# Altium schematic sheet IMX7S_MEMORY.SchDoc
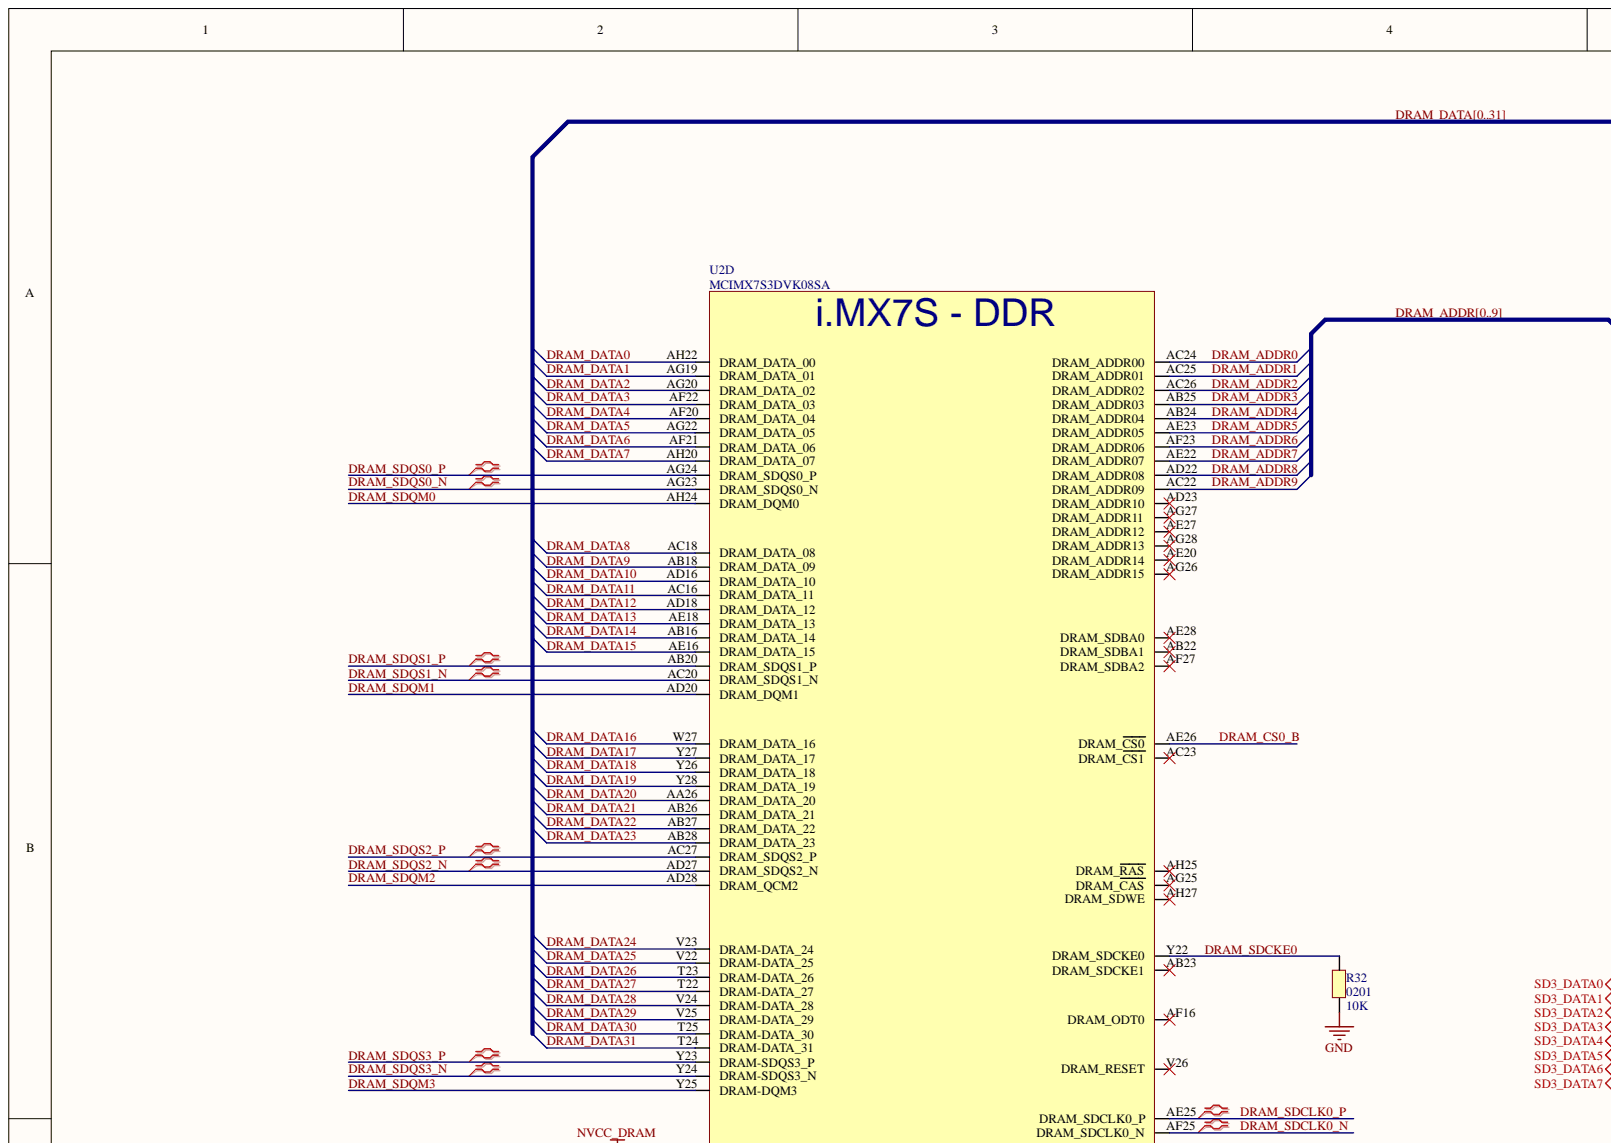
[diagram p0: IMX7S_MEMORY.SchDoc, top left]
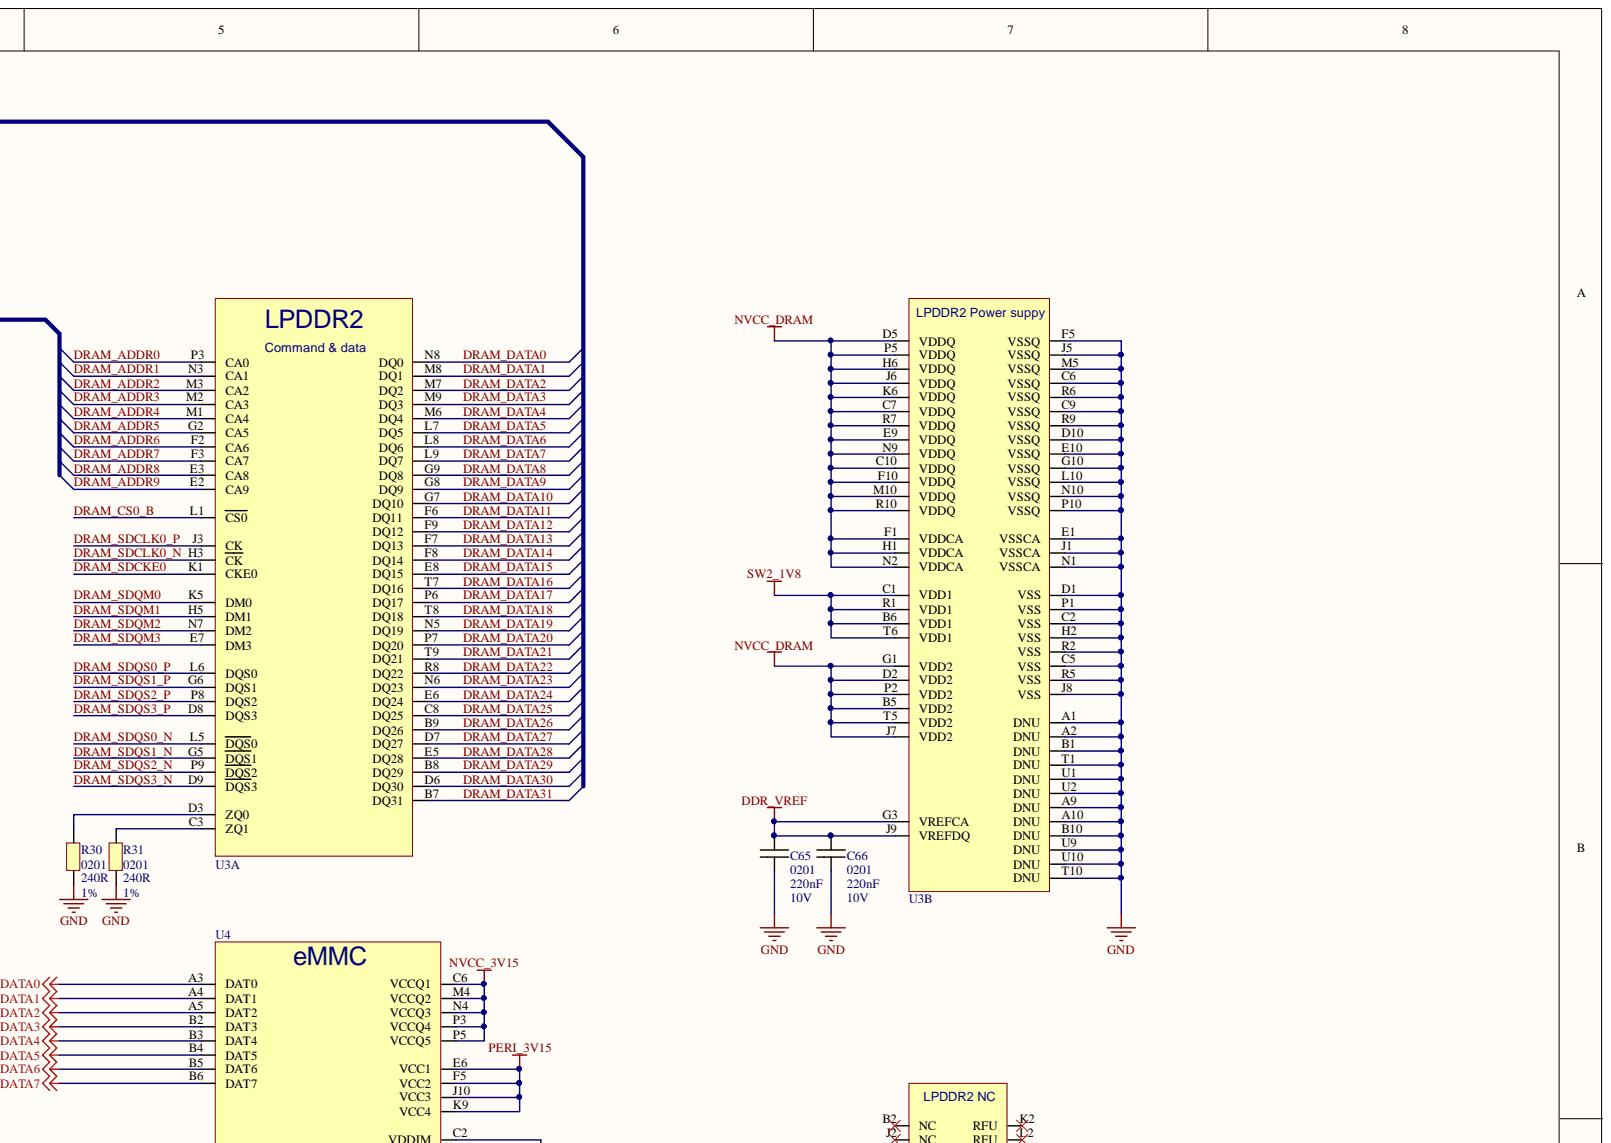
[diagram p1: IMX7S_MEMORY.SchDoc, top right]
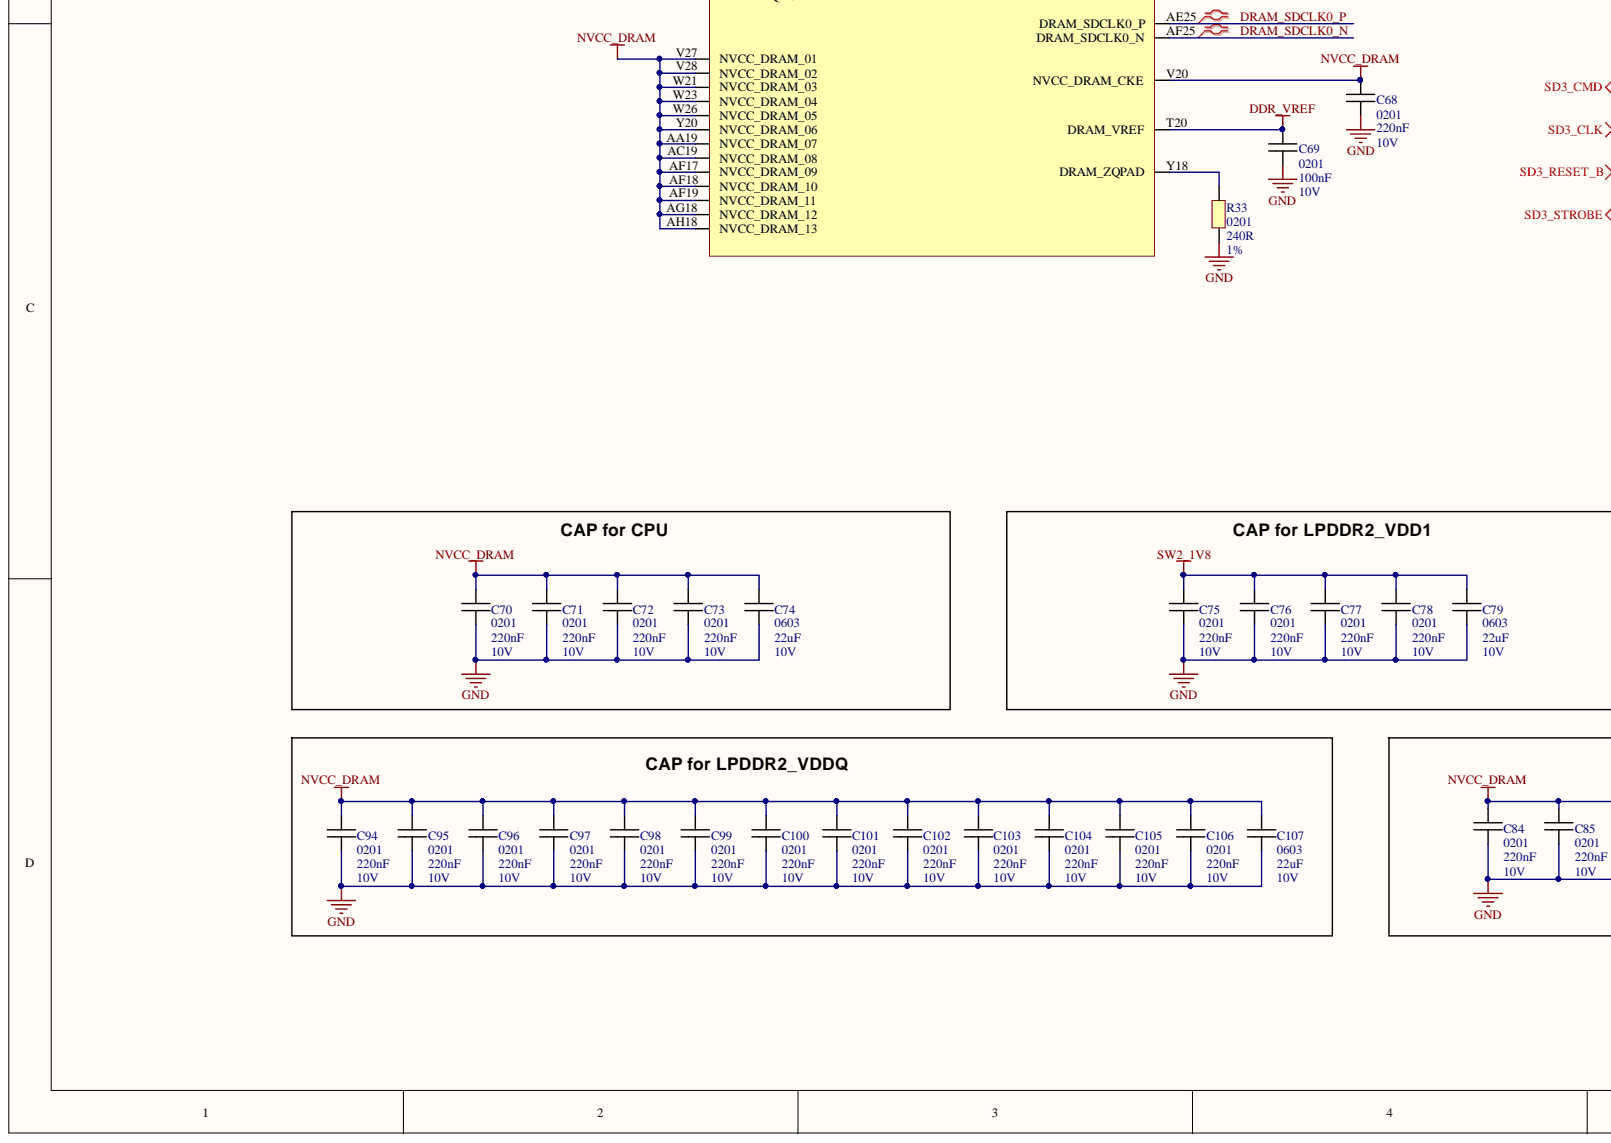
[diagram p2: IMX7S_MEMORY.SchDoc, bottom left]
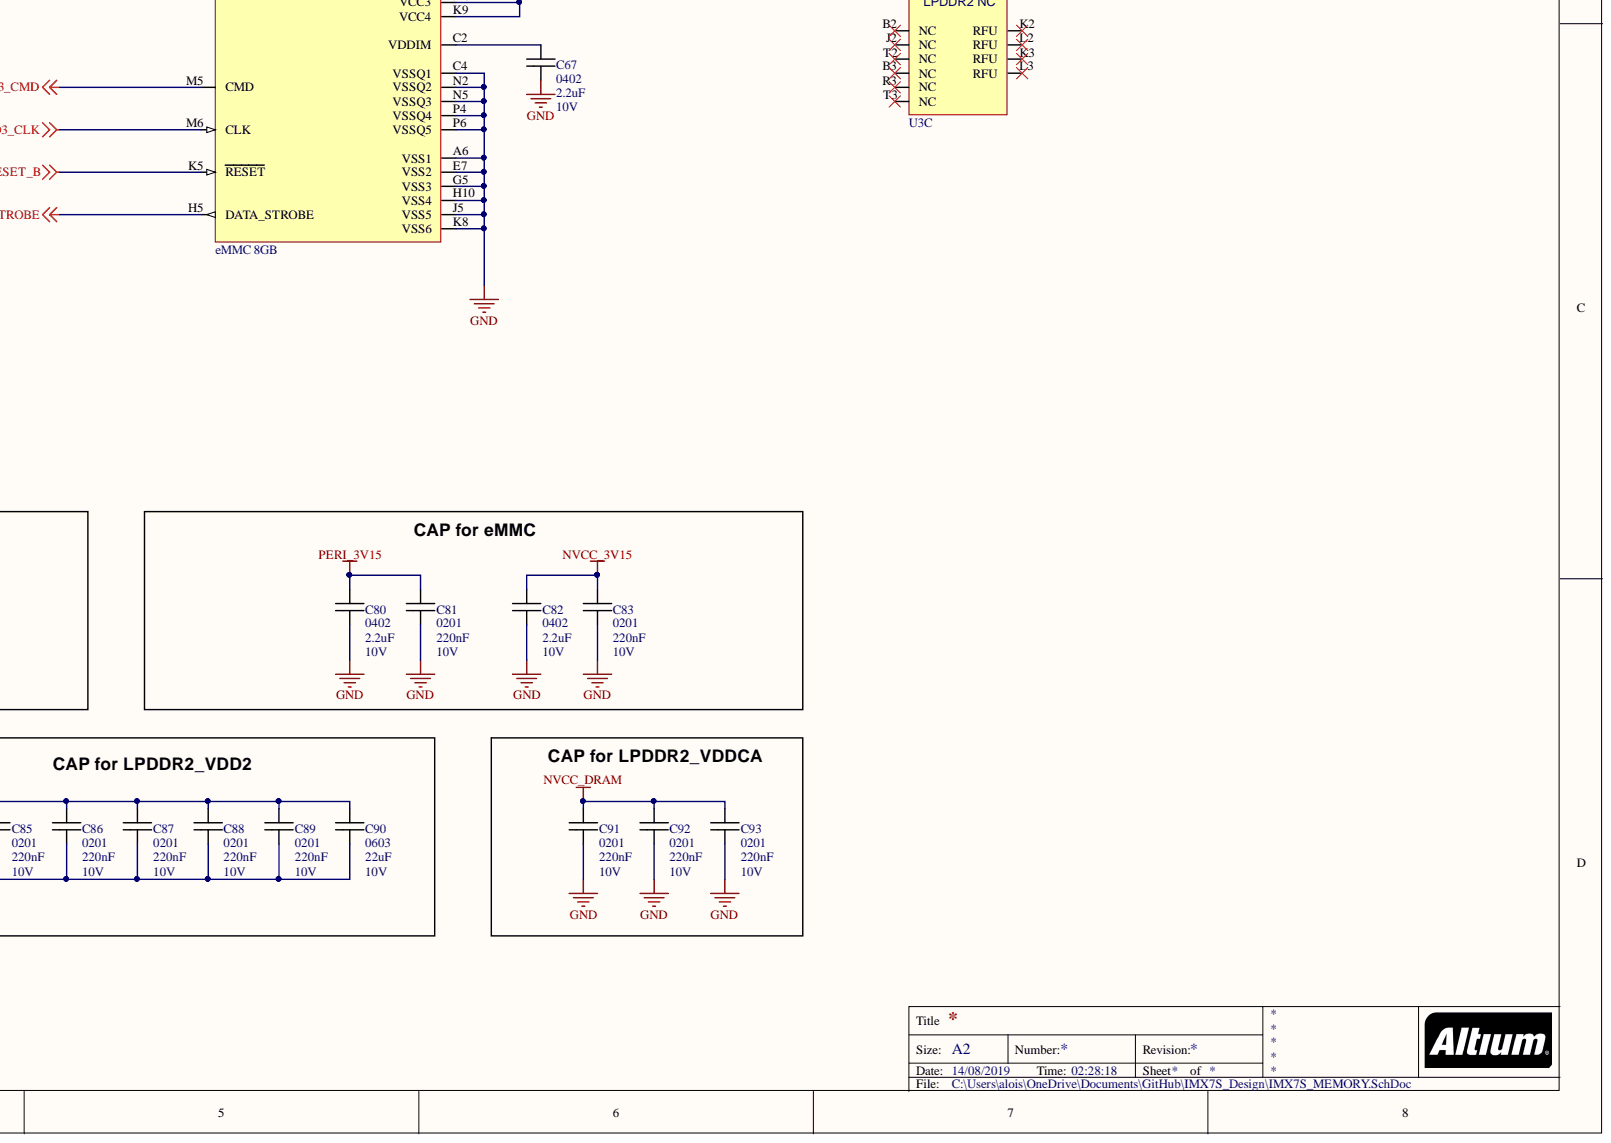
[diagram p3: IMX7S_MEMORY.SchDoc, bottom right]

## Parts
C65  value 220nF  PACKAGE: 0201  VOLTAGE: 10V  [C0201]  (hidden comment: C0201)
C66  value 220nF  PACKAGE: 0201  VOLTAGE: 10V  [C0201]  (hidden comment: C0201)
C67  value 2.2uF  PACKAGE: 0402  VOLTAGE: 10V  [C0402]  (hidden comment: C0402)
C68  value 220nF  PACKAGE: 0201  VOLTAGE: 10V  [C0201]  (hidden comment: C0201)
C69  value 100nF  PACKAGE: 0201  VOLTAGE: 10V  [C0201]  (hidden comment: C0201)
C70  value 220nF  PACKAGE: 0201  VOLTAGE: 10V  [C0201]  (hidden comment: C0201)
C71  value 220nF  PACKAGE: 0201  VOLTAGE: 10V  [C0201]  (hidden comment: C0201)
C72  value 220nF  PACKAGE: 0201  VOLTAGE: 10V  [C0201]  (hidden comment: C0201)
C73  value 220nF  PACKAGE: 0201  VOLTAGE: 10V  [C0201]  (hidden comment: C0201)
C74  value 22uF  PACKAGE: 0603  VOLTAGE: 10V  [C0603]  (hidden comment: C0603)
C75  value 220nF  PACKAGE: 0201  VOLTAGE: 10V  [C0201]  (hidden comment: C0201)
C76  value 220nF  PACKAGE: 0201  VOLTAGE: 10V  [C0201]  (hidden comment: C0201)
C77  value 220nF  PACKAGE: 0201  VOLTAGE: 10V  [C0201]  (hidden comment: C0201)
C78  value 220nF  PACKAGE: 0201  VOLTAGE: 10V  [C0201]  (hidden comment: C0201)
C79  value 22uF  PACKAGE: 0603  VOLTAGE: 10V  [C0603]  (hidden comment: C0603)
C80  value 2.2uF  PACKAGE: 0402  VOLTAGE: 10V  [C0402]  (hidden comment: C0402)
C81  value 220nF  PACKAGE: 0201  VOLTAGE: 10V  [C0201]  (hidden comment: C0201)
C82  value 2.2uF  PACKAGE: 0402  VOLTAGE: 10V  [C0402]  (hidden comment: C0402)
C83  value 220nF  PACKAGE: 0201  VOLTAGE: 10V  [C0201]  (hidden comment: C0201)
C84  value 220nF  PACKAGE: 0201  VOLTAGE: 10V  [C0201]  (hidden comment: C0201)
C85  value 220nF  PACKAGE: 0201  VOLTAGE: 10V  [C0201]  (hidden comment: C0201)
C86  value 220nF  PACKAGE: 0201  VOLTAGE: 10V  [C0201]  (hidden comment: C0201)
C87  value 220nF  PACKAGE: 0201  VOLTAGE: 10V  [C0201]  (hidden comment: C0201)
C88  value 220nF  PACKAGE: 0201  VOLTAGE: 10V  [C0201]  (hidden comment: C0201)
C89  value 220nF  PACKAGE: 0201  VOLTAGE: 10V  [C0201]  (hidden comment: C0201)
C90  value 22uF  PACKAGE: 0603  VOLTAGE: 10V  [C0603]  (hidden comment: C0603)
C91  value 220nF  PACKAGE: 0201  VOLTAGE: 10V  [C0201]  (hidden comment: C0201)
C92  value 220nF  PACKAGE: 0201  VOLTAGE: 10V  [C0201]  (hidden comment: C0201)
C93  value 220nF  PACKAGE: 0201  VOLTAGE: 10V  [C0201]  (hidden comment: C0201)
C94  value 220nF  PACKAGE: 0201  VOLTAGE: 10V  [C0201]  (hidden comment: C0201)
C95  value 220nF  PACKAGE: 0201  VOLTAGE: 10V  [C0201]  (hidden comment: C0201)
C96  value 220nF  PACKAGE: 0201  VOLTAGE: 10V  [C0201]  (hidden comment: C0201)
C97  value 220nF  PACKAGE: 0201  VOLTAGE: 10V  [C0201]  (hidden comment: C0201)
C98  value 220nF  PACKAGE: 0201  VOLTAGE: 10V  [C0201]  (hidden comment: C0201)
C99  value 220nF  PACKAGE: 0201  VOLTAGE: 10V  [C0201]  (hidden comment: C0201)
C100  value 220nF  PACKAGE: 0201  VOLTAGE: 10V  [C0201]  (hidden comment: C0201)
C101  value 220nF  PACKAGE: 0201  VOLTAGE: 10V  [C0201]  (hidden comment: C0201)
C102  value 220nF  PACKAGE: 0201  VOLTAGE: 10V  [C0201]  (hidden comment: C0201)
C103  value 220nF  PACKAGE: 0201  VOLTAGE: 10V  [C0201]  (hidden comment: C0201)
C104  value 220nF  PACKAGE: 0201  VOLTAGE: 10V  [C0201]  (hidden comment: C0201)
C105  value 220nF  PACKAGE: 0201  VOLTAGE: 10V  [C0201]  (hidden comment: C0201)
C106  value 220nF  PACKAGE: 0201  VOLTAGE: 10V  [C0201]  (hidden comment: C0201)
C107  value 22uF  PACKAGE: 0603  VOLTAGE: 10V  [C0603]  (hidden comment: C0603)
R30  R0201  value 240R  PACKAGE: 0201  VOLTAGE: 1%  [R0201]
R31  R0201  value 240R  PACKAGE: 0201  VOLTAGE: 1%  [R0201]
R32  value 10K  PACKAGE: 0201  [R0201]  (hidden comment: R0201)
R33  R0201  value 240R  PACKAGE: 0201  VOLTAGE: 1%  [R0201]
U2  MCIMX7S3DVK08SA  [BGA 488 PIN]  (part D)
U3  EDB2432B4MA-1DAAT-F-R  [DDR_VFBGA]  (value not drawn)  (parts A,B,C)
U4  eMMC 8GB  [VFBGA]

## Nets
DDR_VREF: C65.2 C66.2 C69.2 U2.T20(DRAM_VREF) U3.G3(VREFCA) U3.J9(VREFDQ)
GND: C65.1 C66.1 C67.1 C68.1 C69.1 C70.1 C71.1 C72.1 C73.1 C74.1 C75.1 C76.1 C77.1 C78.1 C79.1 C80.1 C81.1 C82.1 C83.1 C84.1 C85.1 C86.1 C87.1 C88.1 C89.1 C90.1 C91.1 C92.1 C93.1 C94.1 C95.1 C96.1 C97.1 C98.1 C99.1 C100.1 C101.1 C102.1 C103.1 C104.1 C105.1 C106.1 C107.1 R30.1 R31.1 R32.1 R33.1 U3.A1(DNU) U3.A2(DNU) U3.A9(DNU) U3.A10(DNU) U3.B1(DNU) U3.B10(DNU) U3.C2(VSS) U3.C5(VSS) U3.C6(VSSQ) U3.C9(VSSQ) U3.D1(VSS) U3.D10(VSSQ) U3.E1(VSSCA) U3.E10(VSSQ) U3.F5(VSSQ) U3.G10(VSSQ) U3.H2(VSS) U3.J1(VSSCA) U3.J5(VSSQ) U3.J8(VSS) U3.L10(VSSQ) U3.M5(VSSQ) U3.N1(VSSCA) U3.N10(VSSQ) U3.P1(VSS) U3.P10(VSSQ) U3.R2(VSS) U3.R5(VSS) U3.R6(VSSQ) U3.R9(VSSQ) U3.T1(DNU) U3.T10(DNU) U3.U1(DNU) U3.U2(DNU) U3.U9(DNU) U3.U10(DNU) U4.A6(VSS1) U4.C4(VSSQ1) U4.E7(VSS2) U4.G5(VSS3) U4.H10(VSS4) U4.J5(VSS5) U4.K8(VSS6) U4.N2(VSSQ2) U4.N5(VSSQ3) U4.P4(VSSQ4) U4.P6(VSSQ5)
NVCC_3V15: C82.2 C83.2 U4.C6(VCCQ1) U4.M4(VCCQ2) U4.N4(VCCQ3) U4.P3(VCCQ4) U4.P5(VCCQ5)
NVCC_DRAM: C68.2 C70.2 C71.2 C72.2 C73.2 C74.2 C84.2 C85.2 C86.2 C87.2 C88.2 C89.2 C90.2 C91.2 C92.2 C93.2 C94.2 C95.2 C96.2 C97.2 C98.2 C99.2 C100.2 C101.2 C102.2 C103.2 C104.2 C105.2 C106.2 C107.2 U2.AA19(NVCC_DRAM_07) U2.AC19(NVCC_DRAM_08) U2.AF17(NVCC_DRAM_09) U2.AF18(NVCC_DRAM_10) U2.AF19(NVCC_DRAM_11) U2.AG18(NVCC_DRAM_12) U2.AH18(NVCC_DRAM_13) U2.V20(NVCC_DRAM_CKE) U2.V27(NVCC_DRAM_01) U2.V28(NVCC_DRAM_02) U2.W21(NVCC_DRAM_03) U2.W23(NVCC_DRAM_04) U2.W26(NVCC_DRAM_05) U2.Y20(NVCC_DRAM_06) U3.B5(VDD2) U3.C7(VDDQ) U3.C10(VDDQ) U3.D2(VDD2) U3.D5(VDDQ) U3.E9(VDDQ) U3.F1(VDDCA) U3.F10(VDDQ) U3.G1(VDD2) U3.H1(VDDCA) U3.H6(VDDQ) U3.J6(VDDQ) U3.J7(VDD2) U3.K6(VDDQ) U3.M10(VDDQ) U3.N2(VDDCA) U3.N9(VDDQ) U3.P2(VDD2) U3.P5(VDDQ) U3.R7(VDDQ) U3.R10(VDDQ) U3.T5(VDD2)
PERI_3V15: C80.2 C81.2 U4.E6(VCC1) U4.F5(VCC2) U4.J10(VCC3) U4.K9(VCC4)
SD3_CLK: U4.M6(CLK)
SD3_CMD: U4.M5(CMD)
SD3_DATA0: U4.A3(DAT0)
SD3_DATA1: U4.A4(DAT1)
SD3_DATA2: U4.A5(DAT2)
SD3_DATA3: U4.B2(DAT3)
SD3_DATA4: U4.B3(DAT4)
SD3_DATA5: U4.B4(DAT5)
SD3_DATA6: U4.B5(DAT6)
SD3_DATA7: U4.B6(DAT7)
SD3_RESET_B: U4.K5(RESET)
SD3_STROBE: U4.H5(DATA_STROBE)
SW2_1V8: C75.2 C76.2 C77.2 C78.2 C79.2 U3.B6(VDD1) U3.C1(VDD1) U3.R1(VDD1) U3.T6(VDD1)
DRAM_ADDR0: U2.AC24(DRAM_ADDR00) U3.P3(CA0)  [also labelled DRAM_ADDR]
DRAM_ADDR1: U2.AC25(DRAM_ADDR01) U3.N3(CA1)  [also labelled DRAM_ADDR]
DRAM_ADDR2: U2.AC26(DRAM_ADDR02) U3.M3(CA2)  [also labelled DRAM_ADDR]
DRAM_ADDR3: U2.AB25(DRAM_ADDR03) U3.M2(CA3)  [also labelled DRAM_ADDR]
DRAM_ADDR4: U2.AB24(DRAM_ADDR04) U3.M1(CA4)  [also labelled DRAM_ADDR]
DRAM_ADDR5: U2.AE23(DRAM_ADDR05) U3.G2(CA5)  [also labelled DRAM_ADDR]
DRAM_ADDR6: U2.AF23(DRAM_ADDR06) U3.F2(CA6)  [also labelled DRAM_ADDR]
DRAM_ADDR7: U2.AE22(DRAM_ADDR07) U3.F3(CA7)  [also labelled DRAM_ADDR]
DRAM_ADDR8: U2.AD22(DRAM_ADDR08) U3.E3(CA8)  [also labelled DRAM_ADDR]
DRAM_ADDR9: U2.AC22(DRAM_ADDR09) U3.E2(CA9)  [also labelled DRAM_ADDR]
DRAM_CS0_B: U2.AE26(DRAM_CS0) U3.L1(CS0)
DRAM_DATA0: U2.AH22(DRAM_DATA_00) U3.N8(DQ0)  [also labelled DRAM_DATA]
DRAM_DATA1: U2.AG19(DRAM_DATA_01) U3.M8(DQ1)  [also labelled DRAM_DATA]
DRAM_DATA2: U2.AG20(DRAM_DATA_02) U3.M7(DQ2)  [also labelled DRAM_DATA]
DRAM_DATA3: U2.AF22(DRAM_DATA_03) U3.M9(DQ3)  [also labelled DRAM_DATA]
DRAM_DATA4: U2.AF20(DRAM_DATA_04) U3.M6(DQ4)  [also labelled DRAM_DATA]
DRAM_DATA5: U2.AG22(DRAM_DATA_05) U3.L7(DQ5)  [also labelled DRAM_DATA]
DRAM_DATA6: U2.AF21(DRAM_DATA_06) U3.L8(DQ6)  [also labelled DRAM_DATA]
DRAM_DATA7: U2.AH20(DRAM_DATA_07) U3.L9(DQ7)  [also labelled DRAM_DATA]
DRAM_DATA8: U2.AC18(DRAM_DATA_08) U3.G9(DQ8)  [also labelled DRAM_DATA]
DRAM_DATA9: U2.AB18(DRAM_DATA_09) U3.G8(DQ9)  [also labelled DRAM_DATA]
DRAM_DATA10: U2.AD16(DRAM_DATA_10) U3.G7(DQ10)  [also labelled DRAM_DATA]
DRAM_DATA11: U2.AC16(DRAM_DATA_11) U3.F6(DQ11)  [also labelled DRAM_DATA]
DRAM_DATA12: U2.AD18(DRAM_DATA_12) U3.F9(DQ12)  [also labelled DRAM_DATA]
DRAM_DATA13: U2.AE18(DRAM_DATA_13) U3.F7(DQ13)  [also labelled DRAM_DATA]
DRAM_DATA14: U2.AB16(DRAM_DATA_14) U3.F8(DQ14)  [also labelled DRAM_DATA]
DRAM_DATA15: U2.AE16(DRAM_DATA_15) U3.E8(DQ15)  [also labelled DRAM_DATA]
DRAM_DATA16: U2.W27(DRAM_DATA_16) U3.T7(DQ16)  [also labelled DRAM_DATA]
DRAM_DATA17: U2.Y27(DRAM_DATA_17) U3.P6(DQ17)  [also labelled DRAM_DATA]
DRAM_DATA18: U2.Y26(DRAM_DATA_18) U3.T8(DQ18)  [also labelled DRAM_DATA]
DRAM_DATA19: U2.Y28(DRAM_DATA_19) U3.N5(DQ19)  [also labelled DRAM_DATA]
DRAM_DATA20: U2.AA26(DRAM_DATA_20) U3.P7(DQ20)  [also labelled DRAM_DATA]
DRAM_DATA21: U2.AB26(DRAM_DATA_21) U3.T9(DQ21)  [also labelled DRAM_DATA]
DRAM_DATA22: U2.AB27(DRAM_DATA_22) U3.R8(DQ22)  [also labelled DRAM_DATA]
DRAM_DATA23: U2.AB28(DRAM_DATA_23) U3.N6(DQ23)  [also labelled DRAM_DATA]
DRAM_DATA24: U2.V23(DRAM-DATA_24) U3.E6(DQ24)  [also labelled DRAM_DATA]
DRAM_DATA25: U2.V22(DRAM-DATA_25) U3.C8(DQ25)  [also labelled DRAM_DATA]
DRAM_DATA26: U2.T23(DRAM-DATA_26) U3.B9(DQ26)  [also labelled DRAM_DATA]
DRAM_DATA27: U2.T22(DRAM-DATA_27) U3.D7(DQ27)  [also labelled DRAM_DATA]
DRAM_DATA28: U2.V24(DRAM-DATA_28) U3.E5(DQ28)  [also labelled DRAM_DATA]
DRAM_DATA29: U2.V25(DRAM-DATA_29) U3.B8(DQ29)  [also labelled DRAM_DATA]
DRAM_DATA30: U2.T25(DRAM-DATA_30) U3.D6(DQ30)  [also labelled DRAM_DATA]
DRAM_DATA31: U2.T24(DRAM-DATA_31) U3.B7(DQ31)  [also labelled DRAM_DATA]
DRAM_SDCKE0: R32.2 U2.Y22(DRAM_SDCKE0) U3.K1(CKE0)
DRAM_SDCLK0_N: U2.AF25(DRAM_SDCLK0_N) U3.H3(CK)
DRAM_SDCLK0_P: U2.AE25(DRAM_SDCLK0_P) U3.J3(CK)
DRAM_SDQM0: U2.AH24(DRAM_DQM0) U3.K5(DM0)
DRAM_SDQM1: U2.AD20(DRAM_DQM1) U3.H5(DM1)
DRAM_SDQM2: U2.AD28(DRAM_QCM2) U3.N7(DM2)
DRAM_SDQM3: U2.Y25(DRAM-DQM3) U3.E7(DM3)
DRAM_SDQS0_N: U2.AG23(DRAM_SDQS0_N) U3.L5(DQS0)
DRAM_SDQS0_P: U2.AG24(DRAM_SDQS0_P) U3.L6(DQS0)
DRAM_SDQS1_N: U2.AC20(DRAM_SDQS1_N) U3.G5(DQS1)
DRAM_SDQS1_P: U2.AB20(DRAM_SDQS1_P) U3.G6(DQS1)
DRAM_SDQS2_N: U2.AD27(DRAM_SDQS2_N) U3.P9(DQS2)
DRAM_SDQS2_P: U2.AC27(DRAM_SDQS2_P) U3.P8(DQS2)
DRAM_SDQS3_N: U2.Y24(DRAM-SDQS3_N) U3.D9(DQS3)
DRAM_SDQS3_P: U2.Y23(DRAM-SDQS3_P) U3.D8(DQS3)
NetC67_2: C67.2 U4.C2(VDDIM)
NetR30_2: R30.2 U3.D3(ZQ0)
NetR31_2: R31.2 U3.C3(ZQ1)
NetR33_2: R33.2 U2.Y18(DRAM_ZQPAD)

Not connected: U2 AB22(DRAM_SDBA1) AB23(DRAM_SDCKE1) AC23(DRAM_CS1) AD23(DRAM_ADDR10) AE20(DRAM_ADDR14) AE27(DRAM_ADDR12) AE28(DRAM_SDBA0) AF16(DRAM_ODT0) AF27(DRAM_SDBA2) AG25(DRAM_CAS) AG26(DRAM_ADDR15) AG27(DRAM_ADDR11) AG28(DRAM_ADDR13) AH25(DRAM_RAS) AH27(DRAM_SDWE) V26(DRAM_RESET); U3 B2(NC) B3(NC) J2(NC) K2(RFU) K3(RFU) L2(RFU) L3(RFU) R3(NC) T2(NC) T3(NC)

## Library data of the parts
R32 = R0201: VOLTAGE: 0V; Component Kind: Standard; Library Name: Schlib_IMX7.SchLib
U2 = MCIMX7S3DVK08SD: Component Kind: Standard; Library Name: Schlib_IMX7.SchLib; Supplier 1: Mouser; Supplier Part Number 1: 771-MCIMX7S3DVK08SD
U4 = MTFC8GAKAJCN-4M IT: Component Kind: Standard; Library Name: Schlib_IMX7.SchLib
C65 C66 C68-C73 C75-C78 C81 C83-C89 C91-C106 = C0201: Component Kind: Standard; Library Name: Schlib_IMX7.SchLib
C67 C80 C82 = C0402: Component Kind: Standard; Library Name: Schlib_IMX7.SchLib
C74 C79 C90 C107 = C0603: Component Kind: Standard; Library Name: Schlib_IMX7.SchLib
R30 R31 R33 = R0201: Component Kind: Standard; Library Name: Schlib_IMX7.SchLib
U3 = EDB2432B4MA-1DAAT-F-R: Component Kind: Standard; Library Name: Schlib_IMX7.SchLib
